# Altium schematic sheet QDSP-6064_0402LED.SchDoc
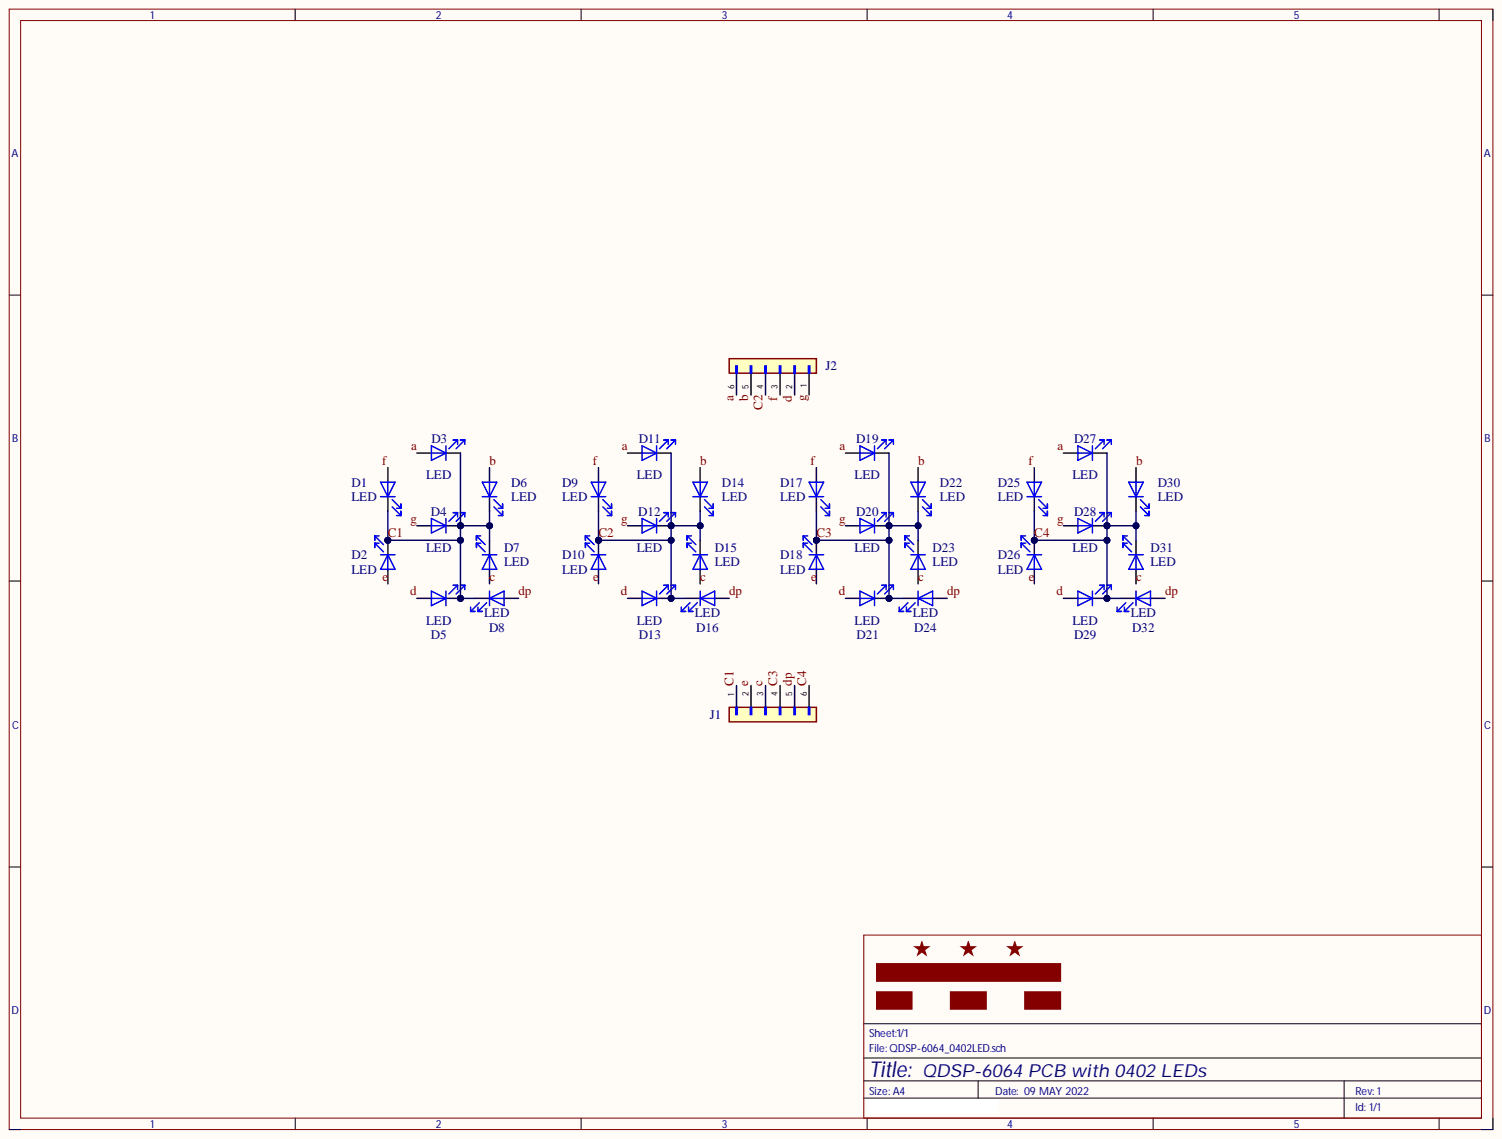
[diagram p0: QDSP-6064_0402LED.SchDoc]

## Parts
D1  LED  [LED_SMD:LED_0402_1005Metric]
D2  LED  [LED_SMD:LED_0402_1005Metric]
D3  LED  [LED_SMD:LED_0402_1005Metric]
D4  LED  [LED_SMD:LED_0402_1005Metric]
D5  LED  [LED_SMD:LED_0402_1005Metric]
D6  LED  [LED_SMD:LED_0402_1005Metric]
D7  LED  [LED_SMD:LED_0402_1005Metric]
D8  LED  [LED_SMD:LED_0402_1005Metric]
D9  LED  [LED_SMD:LED_0402_1005Metric]
D10  LED  [LED_SMD:LED_0402_1005Metric]
D11  LED  [LED_SMD:LED_0402_1005Metric]
D12  LED  [LED_SMD:LED_0402_1005Metric]
D13  LED  [LED_SMD:LED_0402_1005Metric]
D14  LED  [LED_SMD:LED_0402_1005Metric]
D15  LED  [LED_SMD:LED_0402_1005Metric]
D16  LED  [LED_SMD:LED_0402_1005Metric]
D17  LED  [LED_SMD:LED_0402_1005Metric]
D18  LED  [LED_SMD:LED_0402_1005Metric]
D19  LED  [LED_SMD:LED_0402_1005Metric]
D20  LED  [LED_SMD:LED_0402_1005Metric]
D21  LED  [LED_SMD:LED_0402_1005Metric]
D22  LED  [LED_SMD:LED_0402_1005Metric]
D23  LED  [LED_SMD:LED_0402_1005Metric]
D24  LED  [LED_SMD:LED_0402_1005Metric]
D25  LED  [LED_SMD:LED_0402_1005Metric]
D26  LED  [LED_SMD:LED_0402_1005Metric]
D27  LED  [LED_SMD:LED_0402_1005Metric]
D28  LED  [LED_SMD:LED_0402_1005Metric]
D29  LED  [LED_SMD:LED_0402_1005Metric]
D30  LED  [LED_SMD:LED_0402_1005Metric]
D31  LED  [LED_SMD:LED_0402_1005Metric]
D32  LED  [LED_SMD:LED_0402_1005Metric]
J1  Conn_01x06  [Connector_PinHeader_2.54mm:PinHeader_1x06_P2.54mm_Vertical]  (value not drawn)
J2  Conn_01x06  [Connector_PinHeader_2.54mm:PinHeader_1x06_P2.54mm_Vertical]  (value not drawn)

## Nets
a: D3.2 D11.2 D19.2 D27.2 J2.6
b: D6.2 D14.2 D22.2 D30.2 J2.5
c: D7.2 D15.2 D23.2 D31.2 J1.3
C1: D1.1 D2.1 D3.1 D4.1 D5.1 D6.1 D7.1 D8.1 J1.1
C2: D9.1 D10.1 D11.1 D12.1 D13.1 D14.1 D15.1 D16.1 J2.4
C3: D17.1 D18.1 D19.1 D20.1 D21.1 D22.1 D23.1 D24.1 J1.4
C4: D25.1 D26.1 D27.1 D28.1 D29.1 D30.1 D31.1 D32.1 J1.6
d: D5.2 D13.2 D21.2 D29.2 J2.2
dp: D8.2 D16.2 D24.2 D32.2 J1.5
e: D2.2 D10.2 D18.2 D26.2 J1.2
f: D1.2 D9.2 D17.2 D25.2 J2.3
g: D4.2 D12.2 D20.2 D28.2 J2.1

## Library data of the parts
D1-D32 = LED: Component Kind: Standard; Library Name: Device; Value: LED
J1 J2 = Conn_01x06: Component Kind: Standard; Library Name: Connector_Generic; Value: Conn_01x06
